# Altium schematic sheet [08]-CAN,INTERPOSER.SchDoc
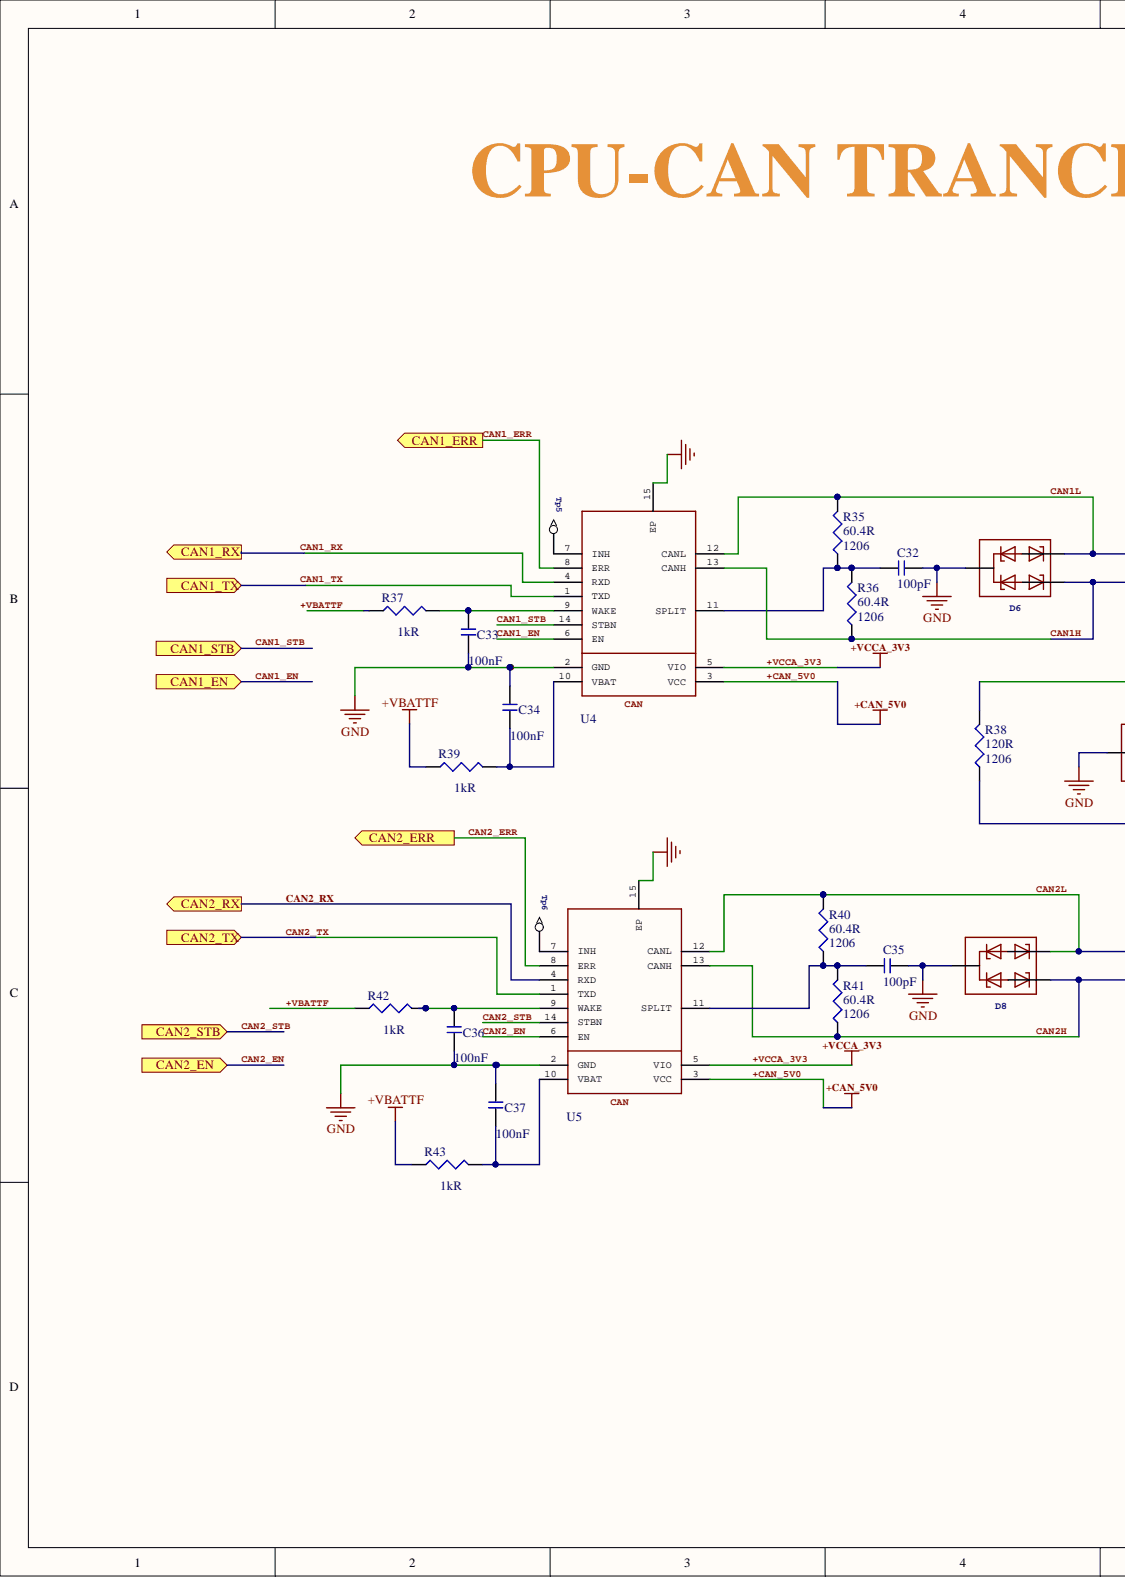
[diagram p0: [08]-CAN,INTERPOSER.SchDoc, left]
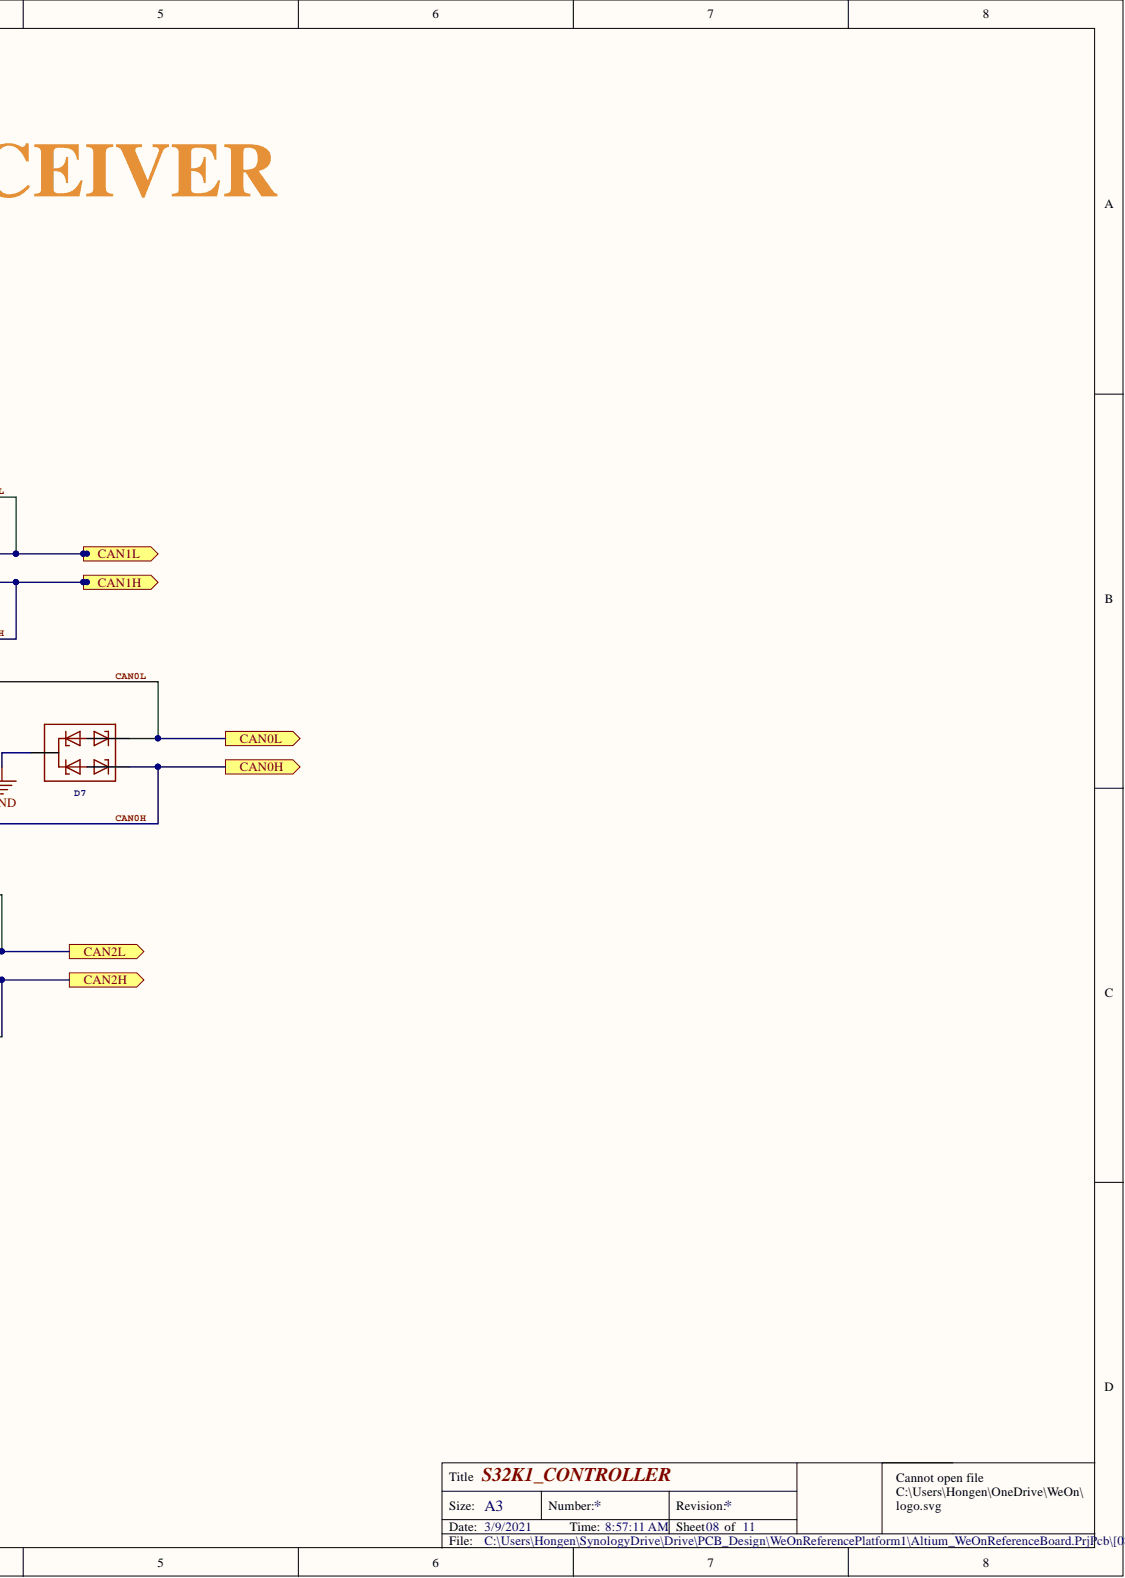
[diagram p1: [08]-CAN,INTERPOSER.SchDoc, right]

## Parts
C32  Capacitance: 100pF  [CAP0402]  (hidden comment: GCM1555C1H101JA16D)
C33  Capacitance: 100nF  [CAP0402]  (hidden comment: GCM155R71C104KA55D)
C34  Capacitance: 100nF  [CAP0402]  (hidden comment: GCM155R71C104KA55D)
C35  Capacitance: 100pF  [CAP0402]  (hidden comment: GCM1555C1H101JA16D)
C36  Capacitance: 100nF  [CAP0402]  (hidden comment: GCM155R71C104KA55D)
C37  Capacitance: 100nF  [CAP0402]  (hidden comment: GCM155R71C104KA55D)
D6  PESD1CAN-U  [PESD1CAN-U_SOT323]  (value not drawn)
D7  PESD1CAN-U  [PESD1CAN-U_SOT323]  (value not drawn)
D8  PESD1CAN-U  [PESD1CAN-U_SOT323]  (value not drawn)
R35  Case/Package: 1206  Resistance: 60.4R  (hidden comment: RMCF1206FT60R4)
R36  Case/Package: 1206  Resistance: 60.4R  (hidden comment: RMCF1206FT60R4)
R37  Resistance: 1kR  [RES0402]  (hidden comment: ERJ-2GEJ102X)
R38  Case/Package: 1206  Resistance: 120R  (hidden comment: RMCF1206JT120R)
R39  Resistance: 1kR  [RES0402]  (hidden comment: ERJ-2GEJ102X)
R40  Case/Package: 1206  Resistance: 60.4R  (hidden comment: RMCF1206FT60R4)
R41  Case/Package: 1206  Resistance: 60.4R  (hidden comment: RMCF1206FT60R4)
R42  Resistance: 1kR  [RES0402]  (hidden comment: ERJ-2GEJ102X)
R43  Resistance: 1kR  [RES0402]  (hidden comment: ERJ-2GEJ102X)
Tp5  TP  [TestPoint]  (value not drawn)
Tp6  TP  [TestPoint]  (value not drawn)
U4  WEON_TJA1043TK  [WEON_DFN-14_DE]  (value not drawn)
U5  WEON_TJA1043TK  [WEON_DFN-14_DE]  (value not drawn)

## Ports
CAN0H, CAN0L, CAN1_EN, CAN1_ERR, CAN1_RX, CAN1_STB, CAN1_TX, CAN1H, CAN1L, CAN2_EN, CAN2_ERR, CAN2_RX, CAN2_STB, CAN2_TX, CAN2H, CAN2L

## Nets
GND: C32.2 C33.2 C34.1 C35.2 C36.2 C37.1 D6.3 D7.3 D8.3 U4.2(GND) U4.15(EP) U5.2(GND) U5.15(EP)
+CAN_5V0: U4.3(VCC) U5.3(VCC)
+VBATTF: R39.1 R42.1 R43.1
+VCCA_3V3: U4.5(VIO) U5.5(VIO)
CAN0H: D7.2 R38.1 ; port CAN0H
CAN0L: D7.1 R38.2 ; port CAN0L
CAN1_EN: U4.6(EN) ; port CAN1_EN
CAN1_ERR: U4.8(ERR) ; port CAN1_ERR
CAN1_RX: U4.4(RXD) ; port CAN1_RX
CAN1_STB: U4.14(STBN) ; port CAN1_STB
CAN1_TX: U4.1(TXD) ; port CAN1_TX
CAN1H: D6.2 R36.1 U4.13(CANH) ; port CAN1H
CAN1L: D6.1 R35.2 U4.12(CANL) ; port CAN1L
CAN2_EN: U5.6(EN) ; port CAN2_EN
CAN2_ERR: U5.8(ERR) ; port CAN2_ERR
CAN2_RX: U5.4(RXD) ; port CAN2_RX
CAN2_STB: U5.14(STBN) ; port CAN2_STB
CAN2_TX: U5.1(TXD) ; port CAN2_TX
CAN2H: D8.2 R41.1 U5.13(CANH) ; port CAN2H
CAN2L: D8.1 R40.2 U5.12(CANL) ; port CAN2L
NetC32_1: C32.1 R35.1 R36.2 U4.11(SPLIT)
NetC33_1: C33.1 R37.2 U4.9(WAKE)
NetC34_2: C34.2 R39.2 U4.10(VBAT)
NetC35_1: C35.1 R40.1 R41.2 U5.11(SPLIT)
NetC36_1: C36.1 R42.2 U5.9(WAKE)
NetC37_2: C37.2 R43.2 U5.10(VBAT)
NetTp5_1: Tp5.1 U4.7(INH)
NetTp6_1: Tp6.1 U5.7(INH)

Not connected: R37 1

## Library data of the parts
C32 C35 = GCM1555C1H101JA16D: 0402 100 pF 50 V ±5 % Tolerance C0G SMT Multilayer Ceramic Capacitor; Manufacturer: Murata; Tolerance: 5%; Voltage: 50V; Case/Package: 0402; Case Code (Imperial): 0402; Case Code (Metric): 1005; Component Kind: Standard; ComponentLink1Description: https://www.verical.com/server-webapp/html/getDatasheet?partID=748988&src-supplier=Verical; ComponentLink1URL: https://www.verical.com/server-webapp/html/getDatasheet?partID=748988&src-supplier=Verical; ComponentLink2Description: http://datasheet.octopart.com/GCM1555C1H101JA16D-Murata-datasheet-46741790.pdf?src-supplier=Element14; ComponentLink2URL: http://datasheet.octopart.com/GCM1555C1H101JA16D-Murata-datasheet-46741790.pdf?src-supplier=Element14; ComponentLink3Description: http://datasheet.octopart.com/GCM1555C1H101JA16D-Murata-datasheet-14404325.pdf?src-supplier=Future+Electronics; ComponentLink3URL: http://datasheet.octopart.com/GCM1555C1H101JA16D-Murata-datasheet-14404325.pdf?src-supplier=Future+Electronics; ComponentLink4Description: http://datasheet.octopart.com/GCM1555C1H101JA16D-Murata-datasheet-42223911.pdf?src-supplier=Future+Electronics; ComponentLink4URL: http://datasheet.octopart.com/GCM1555C1H101JA16D-Murata-datasheet-42223911.pdf?src-supplier=Future+Electronics; Depth: 0.5mm; Dielectric: C0G; Height: 0.5mm; Lead Free: Lead Free; Length: 1mm; Manufacturer Part Number: GCM1555C1H101JA16D; Max Operating Temperature: 125°C; Min Operating Temperature: -55°C; Mount: Surface Mount; Package Quantity: 10000; Packaging: Tape and Reel; Pricing 1: 10000 = 0.02080, 1 = 0.01380 (USD); Radiation Hardening: No; Ratings: AEC-Q200; REACH SVHC: No SVHC; Stock 1: 565000; Supplier 1: Arrow; Termination: SMD/SMT; Thickness: 0.022inch; Voltage Rating: 50V; Voltage Rating (DC): 50V; Weight: 0.000212oz; Width: 0.02inch
C33 C34 C36 C37 = GCM155R71C104KA55D: Multilayer Ceramic Capacitors (MLCC) - SMD/SMT 0402 0.1uF 16volts X7R 10%; Manufacturer: Murata; Voltage: 16V; Case/Package: 0402; Case Code (Imperial): 0402; Case Code (Metric): 1005; Component Kind: Standard; ComponentLink1Description: http://psearch.en.murata.com/capacitor/product/GCM155R71C104KA55%23.pdf?src-supplier=Avnet; ComponentLink1URL: http://psearch.en.murata.com/capacitor/product/GCM155R71C104KA55%23.pdf?src-supplier=Avnet; ComponentLink2Description: http://search.murata.co.jp/Ceramy/image/img/A01X/partnumbering_e_02.pdf?src-supplier=Digi-Key; ComponentLink2URL: http://search.murata.co.jp/Ceramy/image/img/A01X/partnumbering_e_02.pdf?src-supplier=Digi-Key; ComponentLink3Description: http://uk.rs-online.com/webdocs/1423/0900766b81423e06.pdf?src-supplier=RSComponents; ComponentLink3URL: http://uk.rs-online.com/webdocs/1423/0900766b81423e06.pdf?src-supplier=RSComponents; ComponentLink4Description: https://www.verical.com/server-webapp/html/getDatasheet?partID=220774&src-supplier=Verical; ComponentLink4URL: https://www.verical.com/server-webapp/html/getDatasheet?partID=220774&src-supplier=Verical; ComponentLink5Description: http://datasheet.octopart.com/GCM155R71C104KA55D-Murata-datasheet-11777063.pdf?src-supplier=_legacy+Avnet; ComponentLink5URL: http://datasheet.octopart.com/GCM155R71C104KA55D-Murata-datasheet-11777063.pdf?src-supplier=_legacy+Avnet; ComponentLink6Description: http://datasheet.octopart.com/GCM155R71C104KA55D-Murata-datasheet-14404342.pdf?src-supplier=Future+Electronics; ComponentLink6URL: http://datasheet.octopart.com/GCM155R71C104KA55D-Murata-datasheet-14404342.pdf?src-supplier=Future+Electronics; ComponentLink7Description: http://datasheet.octopart.com/GCM155R71C104KA55D-Murata-datasheet-12515163.pdf?src-supplier=Farnell; ComponentLink7URL: http://datasheet.octopart.com/GCM155R71C104KA55D-Murata-datasheet-12515163.pdf?src-supplier=Farnell; ComponentLink8Description: http://datasheet.octopart.com/GCM155R71C104KA55D-Murata-datasheet-44104237.pdf?src-supplier=Future+Electronics; ComponentLink8URL: http://datasheet.octopart.com/GCM155R71C104KA55D-Murata-datasheet-44104237.pdf?src-supplier=Future+Electronics; ComponentLink9Description: http://datasheet.octopart.com/GCM155R71C104KA55D-Murata-datasheet-62117434.pdf?src-supplier=TME; ComponentLink9URL: http://datasheet.octopart.com/GCM155R71C104KA55D-Murata-datasheet-62117434.pdf?src-supplier=TME; Depth: 0.5mm; Dielectric: X7R; Height: 0.5mm; Lead Free: Lead Free; Length: 1mm; Manufacturer Part Number: GCM155R71C104KA55D; Max Operating Temperature: 125°C; Min Operating Temperature: -55°C; Mount: Surface Mount; Package Quantity: 1; Packaging: Tape and Reel; Pricing 1: 10000 = 0.01910, 1 = 0.05560, 10 = 0.04750, 25 = 0.03370, 100 = 0.02190, 250 = 0.02080 (USD); Radiation Hardening: No; Ratings: AEC-Q200; REACH SVHC: No SVHC; Stock 1: 10660000; Supplier 1: Arrow; Supplier Part Number 1: GCM155R71C104KA55D; Termination: SMD/SMT; Thickness: 0.022inch; Tolerance: 10%; Voltage Rating: 16V; Voltage Rating (DC): 16V; Width: 0.02inch
D6-D8 = PESD1CAN-U: CAN bus ESD protection diodeElectroStatic Discharge (ESD) protection diode in a very small SOT323 (SC-70 ) Surface-Mounted Device (SMD) plastic package designed to protect two automotive Controller Area Network (CAN) bus lines from the damage caused by ESD and other transients. AAL Eindhoven MK; GateName_1: G$1; MF: NXP Semiconductors; AVAILABILITY: Unavailable; Component Kind: Standard; DeviceSetName: PESD1CAN-U; Library Name: WeOnReferenceBoard.SCHLIB; LibraryName: PESD1CAN-U; MP: PESD1CAN-U; PACKAGE: SOT-323 NXP Semiconductors; PRICE: None; SymbolName_1: PESD1CAN-U
R35 R36 R40 R41 = RMCF1206FT60R4: Res Thick Film 1206 60.4 Ohm 1% 0.25W(1/4W) ±100ppm/C Pad SMD Automotive T/R; Manufacturer: Stackpole Electronics; Max Power Dissipation: 250mW; Temperature Coefficient: 100ppm/°C; Case Code (Imperial): 1206; Case Code (Metric): 3216; Component Kind: Standard; ComponentLink1Description: http://datasheet.octopart.com/RMCF1206FT60R4-Stackpole-Electronics-datasheet-10788263.pdf?src-supplier=Stackpole+Electronics; ComponentLink1URL: http://datasheet.octopart.com/RMCF1206FT60R4-Stackpole-Electronics-datasheet-10788263.pdf?src-supplier=Stackpole+Electronics; ComponentLink2Description: http://datasheet.octopart.com/RMCF1206FT60R4-Stackpole-Electronics-datasheet-12534581.pdf?src-supplier=Stackpole+Electronics; ComponentLink2URL: http://datasheet.octopart.com/RMCF1206FT60R4-Stackpole-Electronics-datasheet-12534581.pdf?src-supplier=Stackpole+Electronics; ComponentLink3Description: http://datasheet.octopart.com/RMCF1206FT60R4-Stackpole-Electronics-datasheet-28504820.pdf; ComponentLink3URL: http://datasheet.octopart.com/RMCF1206FT60R4-Stackpole-Electronics-datasheet-28504820.pdf; Composition: Thick Film; Contact Plating: Matte,Tin; Features: Automotive AEC-Q200; Height: 0.028inch; Length: 0.126inch; Manufacturer Part Number: RMCF1206FT60R4; Max Operating Temperature: 155°C; Min Operating Temperature: -55°C; Mount: Surface Mount; Number of Terminations: 2; Packaging: Tape and Reel; Pricing 1: 1 = 0.00350, 10 = 0.00340, 100 = 0.00330, 500 = 0.00320, 3000 = 0.00310 (USD); Radiation Hardening: No; Stock 1: 4650; Supplier 1: Arrow; Tolerance: 1%; Width: 0.063inch
R37 R39 R42 R43 = ERJ-2GEJ102X: Res Thick Film 0402 1K Ohm 5% 1/10W ±200ppm/°C Molded SMD SMD Punched Carrier T/R; Manufacturer: Panasonic; Max Power Dissipation: 100mW; Voltage Rating: 50V; Case/Package: 0402; Case Code (Imperial): 0402; Case Code (Metric): 1005; Component Kind: Standard; ComponentLink1Description: https://industrial.panasonic.com/cdbs/www-data/pdf/RDA0000/AOA0000C301.pdf?src-supplier=Future+Electronics; ComponentLink1URL: https://industrial.panasonic.com/cdbs/www-data/pdf/RDA0000/AOA0000C301.pdf?src-supplier=Future+Electronics; ComponentLink2Description: http://www.jameco.com/Jameco/Products/ProdDS/1986781.pdf?src-supplier=Jameco; ComponentLink2URL: http://www.jameco.com/Jameco/Products/ProdDS/1986781.pdf?src-supplier=Jameco; ComponentLink3Description: http://www.farnell.com/datasheets/2059623.pdf?src-supplier=Farnell; ComponentLink3URL: http://www.farnell.com/datasheets/2059623.pdf?src-supplier=Farnell; ComponentLink4Description: http://industrial.panasonic.com/www-data/pdf/AOA0000/AOA0000CE1.pdf?src-supplier=Avnet; ComponentLink4URL: http://industrial.panasonic.com/www-data/pdf/AOA0000/AOA0000CE1.pdf?src-supplier=Avnet; ComponentLink5Description: http://www.alliedelec.com/m/d/51cd0790071e1666bdb9d00c57fcf960.pdf?src-supplier=Allied+Electronics; ComponentLink5URL: http://www.alliedelec.com/m/d/51cd0790071e1666bdb9d00c57fcf960.pdf?src-supplier=Allied+Electronics; ComponentLink6Description: http://industrial.panasonic.com/www-data/pdf/AOA0000/AOA0000CE1.pdf?src-supplier=Avnet+Europe; ComponentLink6URL: http://industrial.panasonic.com/www-data/pdf/AOA0000/AOA0000CE1.pdf?src-supplier=Avnet+Europe; ComponentLink7Description: http://www.farnell.com/datasheets/2059623.pdf?src-supplier=Element14; ComponentLink7URL: http://www.farnell.com/datasheets/2059623.pdf?src-supplier=Element14; ComponentLink8Description: http://industrial.panasonic.com/www-data/pdf/AOA0000/AOA0000CE1.pdf?src-supplier=Avnet+Asia; ComponentLink8URL: http://industrial.panasonic.com/www-data/pdf/AOA0000/AOA0000CE1.pdf?src-supplier=Avnet+Asia; ComponentLink9Description: http://datasheet.octopart.com/ERJ-2GEJ102X-Panasonic-datasheet-13266872.pdf?src-supplier=Newark; ComponentLink9URL: http://datasheet.octopart.com/ERJ-2GEJ102X-Panasonic-datasheet-13266872.pdf?src-supplier=Newark; ComponentLink10Description: http://datasheet.octopart.com/ERJ-2GEJ102X-Panasonic-datasheet-5314550.pdf?src-supplier=Jameco; ComponentLink10URL: http://datasheet.octopart.com/ERJ-2GEJ102X-Panasonic-datasheet-5314550.pdf?src-supplier=Jameco; ComponentLink11Description: http://datasheet.octopart.com/ERJ-2GEJ102X-Panasonic-datasheet-61114972.pdf?src-supplier=Newark; ComponentLink11URL: http://datasheet.octopart.com/ERJ-2GEJ102X-Panasonic-datasheet-61114972.pdf?src-supplier=Newark; ComponentLink12Description: http://datasheet.octopart.com/ERJ-2GEJ102X-Panasonic-datasheet-10554283.pdf?src-supplier=Newark; ComponentLink12URL: http://datasheet.octopart.com/ERJ-2GEJ102X-Panasonic-datasheet-10554283.pdf?src-supplier=Newark; ComponentLink13Description: http://datasheet.octopart.com/ERJ-2GEJ102X-Panasonic-datasheet-37173568.pdf?src-supplier=Electro+Sonic; ComponentLink13URL: http://datasheet.octopart.com/ERJ-2GEJ102X-Panasonic-datasheet-37173568.pdf?src-supplier=Electro+Sonic; ComponentLink14Description: http://datasheet.octopart.com/ERJ-2GEJ102X-Panasonic-datasheet-78375410.pdf; ComponentLink14URL: http://datasheet.octopart.com/ERJ-2GEJ102X-Panasonic-datasheet-78375410.pdf; ComponentLink15Description: http://datasheet.octopart.com/ERJ-2GEJ102X-Panasonic-datasheet-118598.pdf?src-supplier=Digi-Key; ComponentLink15URL: http://datasheet.octopart.com/ERJ-2GEJ102X-Panasonic-datasheet-118598.pdf?src-supplier=Digi-Key; ComponentLink16Description: http://datasheet.octopart.com/ERJ-2GEJ102X-Panasonic-datasheet-5313456.pdf?src-supplier=Farnell; ComponentLink16URL: http://datasheet.octopart.com/ERJ-2GEJ102X-Panasonic-datasheet-5313456.pdf?src-supplier=Farnell; ComponentLink17Description: http://datasheet.octopart.com/ERJ-2GEJ102X-Panasonic-datasheet-11480398.pdf?src-supplier=Arrow; ComponentLink17URL: http://datasheet.octopart.com/ERJ-2GEJ102X-Panasonic-datasheet-11480398.pdf?src-supplier=Arrow; ComponentLink18Description: http://datasheet.octopart.com/ERJ-2GEJ102X-Panasonic---ECG-datasheet-15994758.pdf?src-supplier=Farnell; ComponentLink18URL: http://datasheet.octopart.com/ERJ-2GEJ102X-Panasonic---ECG-datasheet-15994758.pdf?src-supplier=Farnell; ComponentLink19Description: http://datasheet.octopart.com/ERJ2GEJ102X-Panasonic-datasheet-27908757.pdf?src-supplier=Electro+Sonic; ComponentLink19URL: http://datasheet.octopart.com/ERJ2GEJ102X-Panasonic-datasheet-27908757.pdf?src-supplier=Electro+Sonic; Composition: Thick Film; Contact Plating: Tin; Depth: 0.5mm; Features: Automotive AEC-Q200; Height: 0.35mm; Lead Free: Lead Free; Length: 1mm; Library Name: WeOnReferenceBoard.SCHLIB; Manufacturer Part Number: ERJ-2GEJ102X; Max Operating Temperature: 155°C; Military Standard: Not; Min Operating Temperature: -55°C; Mount: Surface Mount; Number of Terminations: 2; Package Quantity: 1; Packaging: Tape and Reel; Power Rating: 100mW; Pricing 1: 10000 = 0.00340, 30000 = 0.00280, 100000 = 0.00270, 1 = 0.00280, 25 = 0.00270, 250 = 0.00260, 3000 = 0.00250 (USD); Radiation Hardening: No; REACH SVHC: No SVHC; Stock 1: 3660000; Supplier 1: Arrow; Supplier Part Number 1: ERJ-2GEJ102X; Temperature Coefficient: 200ppm/°C; Termination: SMD/SMT; Tolerance: 5%; Voltage Rating (DC): 50V; Width: 0.02inch; Working Voltage: 50V
R38 = RMCF1206JT120R: Res Thick Film 1206 120 Ohm 5% 0.25W(1/4W) ±200ppm/C Pad SMD Automotive T/R; Manufacturer: Stackpole Electronics; Max Power Dissipation: 250mW; Temperature Coefficient: 200ppm/°C; Case Code (Imperial): 1206; Case Code (Metric): 3216; Component Kind: Standard; ComponentLink1Description: https://www.verical.com/server-webapp/html/getDatasheet?partID=464227&src-supplier=Verical; ComponentLink1URL: https://www.verical.com/server-webapp/html/getDatasheet?partID=464227&src-supplier=Verical; ComponentLink2Description: http://datasheet.octopart.com/RMCF1206JT120R-Stackpole-Electronics-datasheet-10788263.pdf?src-supplier=Stackpole+Electronics; ComponentLink2URL: http://datasheet.octopart.com/RMCF1206JT120R-Stackpole-Electronics-datasheet-10788263.pdf?src-supplier=Stackpole+Electronics; ComponentLink3Description: http://datasheet.octopart.com/RMCF1206JT120R-Stackpole-Electronics-datasheet-12534581.pdf?src-supplier=Stackpole+Electronics; ComponentLink3URL: http://datasheet.octopart.com/RMCF1206JT120R-Stackpole-Electronics-datasheet-12534581.pdf?src-supplier=Stackpole+Electronics; ComponentLink4Description: http://datasheet.octopart.com/RMCF1206JT120R-Stackpole-Electronics-datasheet-28504820.pdf?src-supplier=Future+Electronics; ComponentLink4URL: http://datasheet.octopart.com/RMCF1206JT120R-Stackpole-Electronics-datasheet-28504820.pdf?src-supplier=Future+Electronics; ComponentLink5Description: http://datasheet.octopart.com/RMCF1206JT120R-Stackpole-Electronics-datasheet-11546843.pdf?src-supplier=Arrow; ComponentLink5URL: http://datasheet.octopart.com/RMCF1206JT120R-Stackpole-Electronics-datasheet-11546843.pdf?src-supplier=Arrow; Composition: Thick Film; Contact Plating: Matte,Tin; Depth: 1.6mm; Features: Automotive AEC-Q200; Height: 0.028inch; Length: 0.126inch; Manufacturer Part Number: RMCF1206JT120R; Max Operating Temperature: 155°C; Military Standard: Not; Min Operating Temperature: -55°C; Mount: Surface Mount; Number of Terminations: 2; Packaging: Tape and Reel; Pricing 1: 5000 = 0.00340, 10000 = 0.00310, 25000 = 0.00260, 1 = 0.00260, 25 = 0.00250, 500 = 0.00230 (USD); Radiation Hardening: No; Stock 1: 10000; Supplier 1: Arrow; Supplier Part Number 1: RMCF1206JT120R; Tolerance: 5%; Width: 0.063inch
Tp5 Tp6 = TP: GateName_1: G$1; TP?: >TP_NAME; Component Kind: Standard; DeviceSetName: TP; LibraryName: WeOnReferencePlatform; SymbolName_1: TP
U4 U5 = WEON_TJA1043TK: GateName_1: G$1; Component Kind: Standard; DeviceSetName: WEON_TJA1043TK; Library Name: WeOnReferenceBoard.IntLib; LibraryName: WeOnReferenceBoard; SymbolName_1: WEON_TJA1043
